# Altium schematic sheet Power_Supply.SchDoc
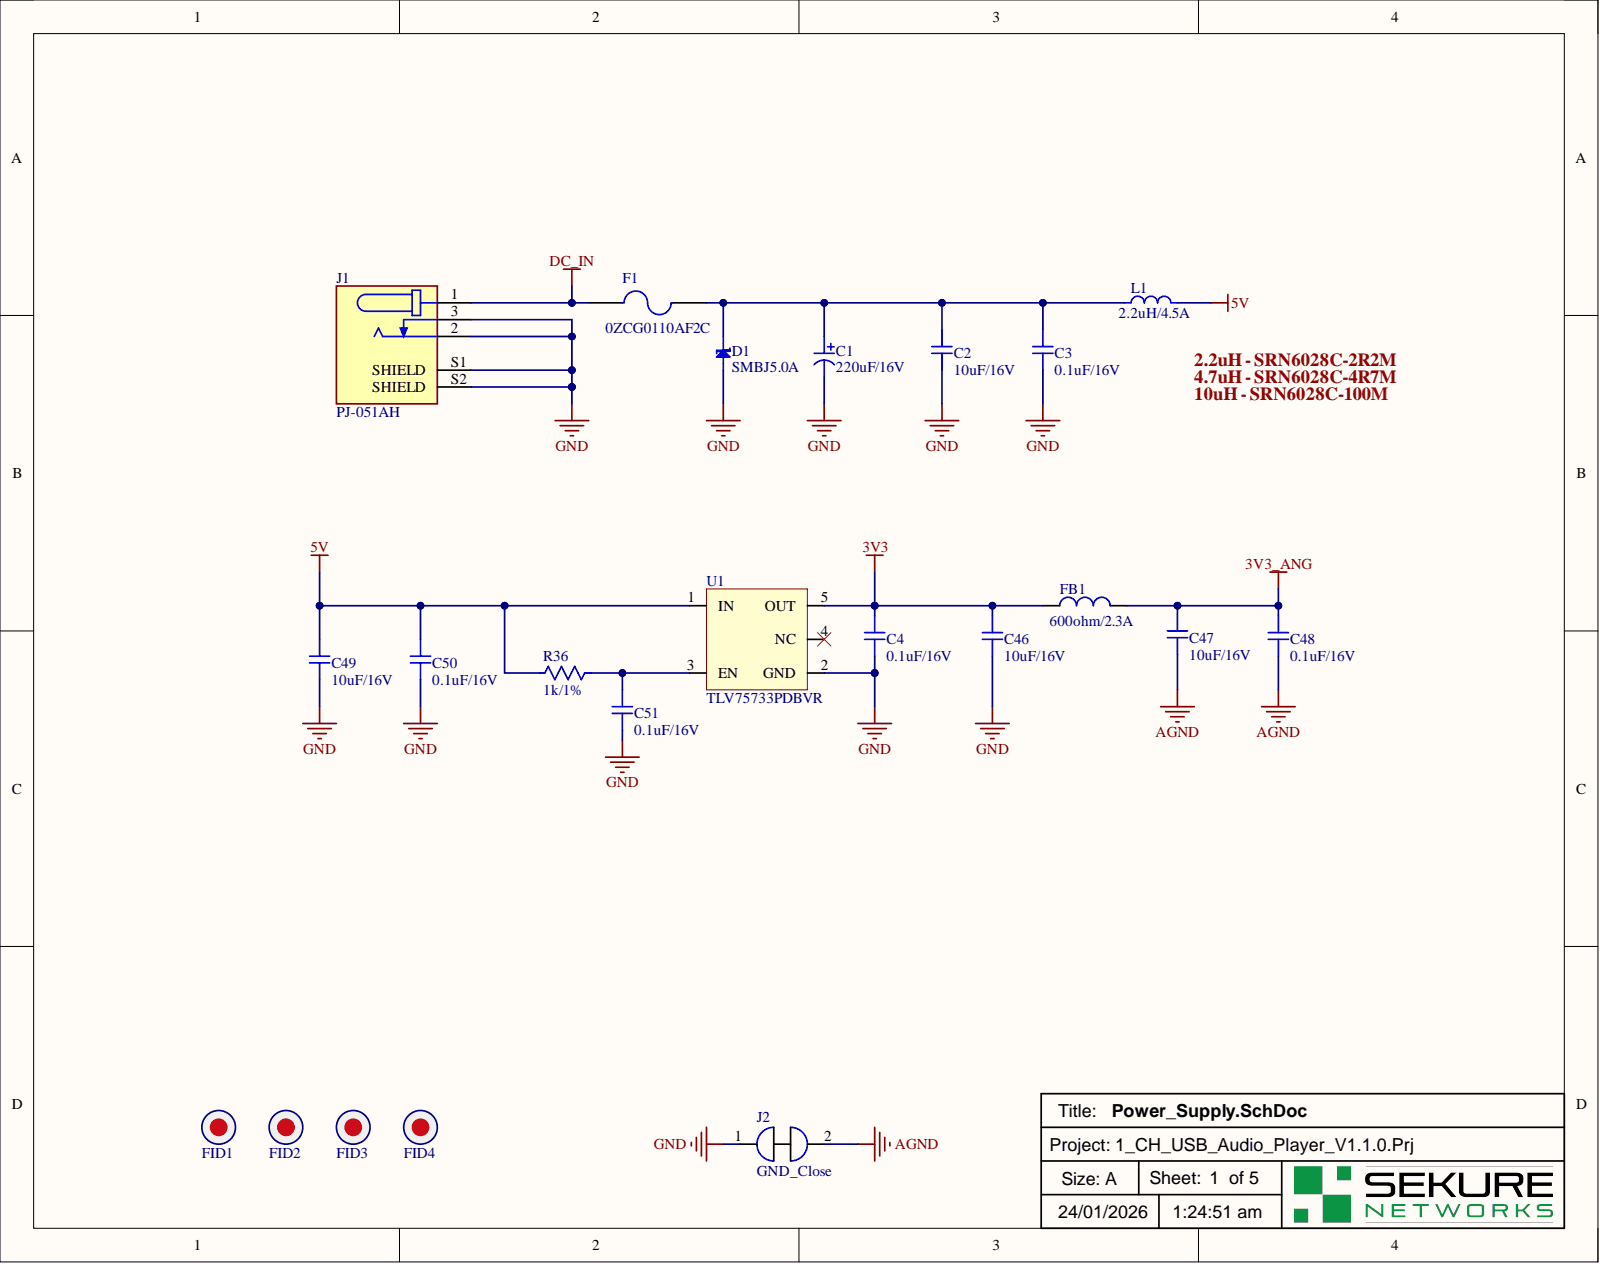
[diagram p0: Power_Supply.SchDoc]

## Parts
C1  value 220uF/16V  [CAP SMD 6.6 x 6.6]  (hidden comment: Radial Capacitor)
C2  value 10uF/16V  [Cap 0805]  (hidden comment: Ceramic Capacitor)
C3  value 0.1uF/16V  [CAP 0603]  (hidden comment: Ceramic Capacitor)
C4  value 0.1uF/16V  [CAP 0603]  (hidden comment: Ceramic Capacitor)
C46  value 10uF/16V  [Cap 0805]  (hidden comment: Ceramic Capacitor)
C47  value 10uF/16V  [Cap 0805]  (hidden comment: Ceramic Capacitor)
C48  value 0.1uF/16V  [CAP 0603]  (hidden comment: Ceramic Capacitor)
C49  value 10uF/16V  [Cap 0805]  (hidden comment: Ceramic Capacitor)
C50  value 0.1uF/16V  [CAP 0603]  (hidden comment: Ceramic Capacitor)
C51  value 0.1uF/16V  [CAP 0603]  (hidden comment: Ceramic Capacitor)
D1  value SMBJ5.0A  [DO-214AA, SMB]  (hidden comment: TVS Diode)
F1  0ZCG0110AF2C  [1812]
FB1  value 600ohm/2.3A  [IND 0805]  (hidden comment: Ferrite Bead)
FID1  Fiducal marks  [Fiducial mark]  (value not drawn)  (no BOM)
FID2  Fiducal marks  [Fiducial mark]  (value not drawn)  (no BOM)
FID3  Fiducal marks  [Fiducial mark]  (value not drawn)  (no BOM)
FID4  Fiducal marks  [Fiducial mark]  (value not drawn)  (no BOM)
J1  value PJ-051AH  [PJ-051AH]  (hidden comment: Connector)
J2  value GND_Close  [Net tie]  (hidden comment: Solder Bridge)
L1  value 2.2uH/4.5A  [INDP6060X45N]  (hidden comment: Inductor)
R36  value 1k/1%  [RES 0603]  (hidden comment: Resistor)
U1  value TLV75733PDBVR  [SOT23-5]  (hidden comment: Regulator)

## Nets
3V3: C4.1 C46.2 FB1.1 U1.5(OUT)
3V3_ANG: C47.2 C48.1 FB1.2
5V: C49.2 C50.2 L1.2 R36.2 U1.1(IN)
AGND: C47.1 C48.2 J2.2
DC_IN: F1.1 J1.1
GND: C1.2 C2.1 C3.2 C4.2 C46.1 C49.1 C50.1 C51.1 D1.2 J1.2 J1.3 J1.S1(SHIELD) J1.S2(SHIELD) J2.1 U1.2(GND)
NetC1_1: C1.1 C2.2 C3.1 D1.1 F1.2 L1.1
NetC51_2: C51.2 R36.1 U1.3(EN)

Not connected: FID1 0; FID2 0; FID3 0; FID4 0; U1 4(NC)

## Library data of the parts
C1 = EEEFK1C221XP: Radial Can - SMD ALUMINUM ELECTROLYTIC CAPACITOR FK 16V 220muF 卤20% 0.248Dia 6.30mm; Manufacturer: Panasonic; Manufacturer Lifecycle Status: ACTIVE; ESR (Equivalent Series Resistance): 340mΩ; Leakage Current: 35.2µA; Life (Hours): 2000hours; Material: Aluminium; Polarity: Polar; Ripple Current: 280mA; Tolerance: 20%; Case/Package: Radial; Category: Aluminum Electrolytic Capacitors; Component Kind: Standard; ComponentLink1Description: https://datasheet.octopart.com:443/EEEFK1C221XP-Panasonic-datasheet-169278531.pdf?src-supplier=IHS; ComponentLink1URL: https://datasheet.octopart.com:443/EEEFK1C221XP-Panasonic-datasheet-169278531.pdf?src-supplier=IHS; ComponentLink2Description: https://datasheet.octopart.com:443/EEEFK1C221XP-Panasonic-datasheet-62287436.pdf?src-supplier=Newark; ComponentLink2URL: https://datasheet.octopart.com:443/EEEFK1C221XP-Panasonic-datasheet-62287436.pdf?src-supplier=Newark; ComponentLink3Description: https://datasheet.octopart.com:443/EEEFK1C221XP-Panasonic-datasheet-8854493.pdf?src-supplier=Newark; ComponentLink3URL: https://datasheet.octopart.com:443/EEEFK1C221XP-Panasonic-datasheet-8854493.pdf?src-supplier=Newark; ComponentLink4Description: https://datasheet.octopart.com:443/EEEFK1C221XP-Panasonic-datasheet-61627622.pdf?src-supplier=Newark; ComponentLink4URL: https://datasheet.octopart.com:443/EEEFK1C221XP-Panasonic-datasheet-61627622.pdf?src-supplier=Newark; ComponentLink5Description: https://datasheet.octopart.com:443/EEE-FK1C221XP-Panasonic---ECG-datasheet-8852369.pdf?src-supplier=Newark; ComponentLink5URL: https://datasheet.octopart.com:443/EEE-FK1C221XP-Panasonic---ECG-datasheet-8852369.pdf?src-supplier=Newark; ComponentLink6Description: https://datasheet.octopart.com:443/EEE-FK1C221XP-Panasonic---ECG-datasheet-8712906.pdf?src-supplier=Newark; ComponentLink6URL: https://datasheet.octopart.com:443/EEE-FK1C221XP-Panasonic---ECG-datasheet-8712906.pdf?src-supplier=Newark; ComponentLink7Description: https://datasheet.octopart.com:443/EEE-FK1C221XP-Panasonic---ECG-datasheet-13278598.pdf?src-supplier=Newark; ComponentLink7URL: https://datasheet.octopart.com:443/EEE-FK1C221XP-Panasonic---ECG-datasheet-13278598.pdf?src-supplier=Newark; ComponentLink8Description: https://datasheet.octopart.com:443/EEE-FK1C221XP-Panasonic-datasheet-22087609.pdf?src-supplier=Newark; ComponentLink8URL: https://datasheet.octopart.com:443/EEE-FK1C221XP-Panasonic-datasheet-22087609.pdf?src-supplier=Newark; ComponentLink9Description: https://datasheet.octopart.com:443/EEEFK1C221XP-Panasonic-datasheet-138897395.pdf?src-supplier=Upverter; ComponentLink9URL: https://datasheet.octopart.com:443/EEEFK1C221XP-Panasonic-datasheet-138897395.pdf?src-supplier=Upverter; ComponentLink10Description: https://datasheet.octopart.com:443/EEEFK1C221XP-Panasonic-datasheet-13266987.pdf?src-supplier=RS+(Formerly+Allied+Electronics); ComponentLink10URL: https://datasheet.octopart.com:443/EEEFK1C221XP-Panasonic-datasheet-13266987.pdf?src-supplier=RS+(Formerly+Allied+Electronics); ComponentLink11Description: https://datasheet.octopart.com:443/EEEFK1C221XP-Panasonic-datasheet-8711795.pdf?src-supplier=Future+Electronics; ComponentLink11URL: https://datasheet.octopart.com:443/EEEFK1C221XP-Panasonic-datasheet-8711795.pdf?src-supplier=Future+Electronics; ComponentLink12Description: https://datasheet.octopart.com:443/EEEFK1C221XP-Panasonic-datasheet-5313801.pdf?src-supplier=Future+Electronics; ComponentLink12URL: https://datasheet.octopart.com:443/EEEFK1C221XP-Panasonic-datasheet-5313801.pdf?src-supplier=Future+Electronics; ComponentLink13Description: https://datasheet.octopart.com:443/EEE-FK1C221XP-Panasonic-datasheet-150469824.pdf?src-supplier=Master+Electronics; ComponentLink13URL: https://datasheet.octopart.com:443/EEE-FK1C221XP-Panasonic-datasheet-150469824.pdf?src-supplier=Master+Electronics; ComponentLink14Description: https://datasheet.octopart.com:443/EEEFK1C221XP-Panasonic-datasheet-11546739.pdf?src-supplier=Electro+Sonic; ComponentLink14URL: https://datasheet.octopart.com:443/EEEFK1C221XP-Panasonic-datasheet-11546739.pdf?src-supplier=Electro+Sonic; ComponentLink15Description: https://sigma.octopart.com:443/150570760/technical_drawing/Panasonic-EEEFK1C221XP.pdf?src-supplier=Panasonic+SCT; ComponentLink15URL: https://sigma.octopart.com:443/150570760/technical_drawing/Panasonic-EEEFK1C221XP.pdf?src-supplier=Panasonic+SCT; ComponentLink16Description: https://datasheet.octopart.com:443/EEEFK1C221XP-Panasonic-datasheet-136531309.pdf?src-supplier=TME; ComponentLink16URL: https://datasheet.octopart.com:443/EEEFK1C221XP-Panasonic-datasheet-136531309.pdf?src-supplier=TME; ComponentLink17Description: https://datasheet.octopart.com:443/EEEFK1C221XP-Panasonic-datasheet-8360981.pdf?src-supplier=Farnell; ComponentLink17URL: https://datasheet.octopart.com:443/EEEFK1C221XP-Panasonic-datasheet-8360981.pdf?src-supplier=Farnell; ComponentLink18Description: https://datasheet.octopart.com:443/EEEFK1C221XP-Panasonic-datasheet-48.pdf?src-supplier=Farnell; ComponentLink18URL: https://datasheet.octopart.com:443/EEEFK1C221XP-Panasonic-datasheet-48.pdf?src-supplier=Farnell; ComponentLink19Description: https://datasheet.octopart.com:443/EEE-FK1C221XP-Panasonic-datasheet-8161.pdf?src-supplier=DigiKey; ComponentLink19URL: https://datasheet.octopart.com:443/EEE-FK1C221XP-Panasonic-datasheet-8161.pdf?src-supplier=DigiKey; Contact Plating: Tin Bismuth; Diameter: 6.3mm; DigiKey: PCE3788CT-ND; Height: 7.7mm; Height - Seated (Max): 8.001mm; Impedance: 340mΩ; Lead Free: Lead Free; Length: 7.7mm; Library Name: Radial Capacitors.IntLib; Lifecycle Status: Production; Max Operating Temperature: 105°C; Min Operating Temperature: -55°C; Mounting Technology: Surface Mount; Number of Terminals: 2; P/N: EEEFK1C221XP; Packaging: Cut Tape; Part Series: FK; Pricing: 1=0.61, 10=0.383, 50=0.2856, 100=0.2546 (USD); Radiation Hardening: No; REACH SVHC: Yes; Ripple Current (AC): 280mA; RoHS: Compliant; Schedule B: 8532220020, 8532220020|8532220020|8532220020|8532220020|8532220020; Stock: 13441; Supplier: DigiKey; Termination: SMD/SMT; Voltage: 16V; Voltage Rating: 16V; Voltage Rating (DC): 16V; Width: 6.6mm
C2 C46 C47 C49 = CL21A106KOQNNNE: 16V 10uF X5R ±10% 0805 Multilayer Ceramic Capacitors MLCC - SMD/SMT ROHS; Manufacturer: Samsung Electro-Mechanics; Case Code (Imperial): 0805; Case Code (Metric): 2012; Case/Package: 0805; Category: Ceramic Capacitors; China RoHS: Compliant; Component Kind: Standard; ComponentLink1Description: https://datasheet.octopart.com:443/CL21A106KOQNNNE-Samsung-Electro-Mechanics-datasheet-136031799.pdf?src-supplier=IHS; ComponentLink1URL: https://datasheet.octopart.com:443/CL21A106KOQNNNE-Samsung-Electro-Mechanics-datasheet-136031799.pdf?src-supplier=IHS; ComponentLink2Description: https://datasheet.octopart.com/CL21A106KOQNNNE-Samsung-Electro-Mechanics-datasheet-8324245.pdf; ComponentLink2URL: https://datasheet.octopart.com/CL21A106KOQNNNE-Samsung-Electro-Mechanics-datasheet-8324245.pdf; ComponentLink3Description: https://datasheet.octopart.com/CL21A106KOQNNNE-Samsung-Electro-Mechanics-datasheet-97040617.pdf; ComponentLink3URL: https://datasheet.octopart.com/CL21A106KOQNNNE-Samsung-Electro-Mechanics-datasheet-97040617.pdf; ComponentLink4Description: https://datasheet.octopart.com:443/CL21A106KOQNNNE-Samsung-Electro-Mechanics-datasheet-130012977.pdf?src-supplier=Future+Electronics; ComponentLink4URL: https://datasheet.octopart.com:443/CL21A106KOQNNNE-Samsung-Electro-Mechanics-datasheet-130012977.pdf?src-supplier=Future+Electronics; ComponentLink5Description: https://datasheet.octopart.com:443/CL21A106KOQNNNE-Samsung-Electro-Mechanics-datasheet-82614846.pdf?src-supplier=Future+Electronics; ComponentLink5URL: https://datasheet.octopart.com:443/CL21A106KOQNNNE-Samsung-Electro-Mechanics-datasheet-82614846.pdf?src-supplier=Future+Electronics; ComponentLink6Description: https://datasheet.octopart.com:443/CL21A106KOQNNNE-Samsung-Electro-Mechanics-datasheet-81462122.pdf?src-supplier=Future+Electronics; ComponentLink6URL: https://datasheet.octopart.com:443/CL21A106KOQNNNE-Samsung-Electro-Mechanics-datasheet-81462122.pdf?src-supplier=Future+Electronics; ComponentLink7Description: https://datasheet.octopart.com:443/CL21A106KOQNNNE-Samsung-datasheet-48647542.pdf?src-supplier=Future+Electronics; ComponentLink7URL: https://datasheet.octopart.com:443/CL21A106KOQNNNE-Samsung-datasheet-48647542.pdf?src-supplier=Future+Electronics; ComponentLink8Description: https://datasheet.octopart.com:443/CL21A106KOQNNNE-Samsung-datasheet-26669228.pdf?src-supplier=Future+Electronics; ComponentLink8URL: https://datasheet.octopart.com:443/CL21A106KOQNNNE-Samsung-datasheet-26669228.pdf?src-supplier=Future+Electronics; ComponentLink9Description: https://datasheet.octopart.com:443/CL21A106KOQNNNE-Samsung-Electro-Mechanics-datasheet-149154152.pdf?src-supplier=Future+Electronics; ComponentLink9URL: https://datasheet.octopart.com:443/CL21A106KOQNNNE-Samsung-Electro-Mechanics-datasheet-149154152.pdf?src-supplier=Future+Electronics; ComponentLink10Description: https://datasheet.octopart.com:443/CL21A106KOQNNNE-Samsung-datasheet-22147434.pdf?src-supplier=TME; ComponentLink10URL: https://datasheet.octopart.com:443/CL21A106KOQNNNE-Samsung-datasheet-22147434.pdf?src-supplier=TME; Depth: 1.25mm; Dielectric: X5R; Dielectric Material: Ceramic; DigiKey: 1276-1096-1-ND; Height: 1.4mm; Lead Free: Lead Free; Length: 2mm; Library Name: Ceramic Capacitors.IntLib; Lifecycle Status: Production; Material: Ceramic; Max Operating Temperature: 85°C; Min Operating Temperature: -55°C; Mounting Technology: Surface Mount; Number of Terminals: 2; P/N: CL21A106KOQNNNE; Pricing: 1=0.08, 10=0.021, 50=0.02, 100=0.0192, 500=0.0144, 1000=0.0128 (USD); Radiation Hardening: No; REACH SVHC: Yes; RoHS: Compliant; Schedule B: 8532240020, 8532240020|8532240020|8532240020|8532240020|8532240020|8532240020|8532240020|8532240020|8532240020|8532240020|8532240020|8532240020|8532240020|8532240020|8532240020|8532240020|8532240020|8532240020|8532240020|8532240020|8532240020|8532240020|8532240020|85; Stock: 1352697; Supplier: DigiKey; Thickness: 1.397mm; Tolerance: 10%; Voltage: 16V; Voltage Rating: 16V; Voltage Rating (DC): 16V; Width: 1.25mm
C3 C4 C48 C50 C51 = CL10B104KO8NNNC: 16V 100nF X7R ±10% 0603 Multilayer Ceramic Capacitors MLCC - SMD/SMT ROHS; Manufacturer: Samsung Electro-Mechanics; Case Code (Imperial): 0603; Case Code (Metric): 1608; Case/Package: 0603; Category: Ceramic Capacitors; China RoHS: Compliant; Component Kind: Standard; ComponentLink1Description: https://datasheet.octopart.com:443/CL10B104KO8NNNC-Samsung-Electro-Mechanics-datasheet-136482305.pdf?src-supplier=IHS; ComponentLink1URL: https://datasheet.octopart.com:443/CL10B104KO8NNNC-Samsung-Electro-Mechanics-datasheet-136482305.pdf?src-supplier=IHS; ComponentLink2Description: https://datasheet.octopart.com/CL10B104KO8NNNC-Samsung-Electro-Mechanics-datasheet-8324245.pdf; ComponentLink2URL: https://datasheet.octopart.com/CL10B104KO8NNNC-Samsung-Electro-Mechanics-datasheet-8324245.pdf; ComponentLink3Description: https://datasheet.octopart.com/CL10B104KO8NNNC-Samsung-Electro-Mechanics-datasheet-97040617.pdf; ComponentLink3URL: https://datasheet.octopart.com/CL10B104KO8NNNC-Samsung-Electro-Mechanics-datasheet-97040617.pdf; ComponentLink4Description: https://datasheet.octopart.com:443/CL10B104KO8NNNC-Samsung-Electro-Mechanics-datasheet-116890973.pdf?src-supplier=Future+Electronics; ComponentLink4URL: https://datasheet.octopart.com:443/CL10B104KO8NNNC-Samsung-Electro-Mechanics-datasheet-116890973.pdf?src-supplier=Future+Electronics; ComponentLink5Description: https://datasheet.octopart.com:443/CL10B104KO8NNNC-Samsung-Electro-Mechanics-datasheet-81461844.pdf?src-supplier=Future+Electronics; ComponentLink5URL: https://datasheet.octopart.com:443/CL10B104KO8NNNC-Samsung-Electro-Mechanics-datasheet-81461844.pdf?src-supplier=Future+Electronics; ComponentLink6Description: https://datasheet.octopart.com:443/CL10B104KO8NNNC-Samsung-datasheet-42770384.pdf?src-supplier=Future+Electronics; ComponentLink6URL: https://datasheet.octopart.com:443/CL10B104KO8NNNC-Samsung-datasheet-42770384.pdf?src-supplier=Future+Electronics; ComponentLink7Description: https://datasheet.octopart.com:443/CL10B104KO8NNNC-Samsung-datasheet-26589157.pdf?src-supplier=Future+Electronics; ComponentLink7URL: https://datasheet.octopart.com:443/CL10B104KO8NNNC-Samsung-datasheet-26589157.pdf?src-supplier=Future+Electronics; ComponentLink8Description: https://datasheet.octopart.com:443/CL10B104KO8NNNC-Samsung-Electro-Mechanics-datasheet-149136789.pdf?src-supplier=Future+Electronics; ComponentLink8URL: https://datasheet.octopart.com:443/CL10B104KO8NNNC-Samsung-Electro-Mechanics-datasheet-149136789.pdf?src-supplier=Future+Electronics; ComponentLink9Description: https://datasheet.octopart.com:443/CL10B104KO8NNNC-Samsung-datasheet-8326407.pdf?src-supplier=TME; ComponentLink9URL: https://datasheet.octopart.com:443/CL10B104KO8NNNC-Samsung-datasheet-8326407.pdf?src-supplier=TME; ComponentLink10Description: https://datasheet.octopart.com:443/CL10B104KO8NNNC-Samsung-Electro-Mechanics-datasheet-11890603.pdf?src-supplier=Arrow+Electronics; ComponentLink10URL: https://datasheet.octopart.com:443/CL10B104KO8NNNC-Samsung-Electro-Mechanics-datasheet-11890603.pdf?src-supplier=Arrow+Electronics; Contact Plating: Tin; Depth: 800µm; Dielectric: X7R; Dielectric Material: Ceramic; DigiKey: 1276-1005-1-ND; Height: 800µm; Lead Free: Lead Free; Length: 1.6mm; Library Name: Ceramic Capacitors.IntLib; Lifecycle Status: Production; Material: Ceramic; Max Operating Temperature: 125°C; Min Operating Temperature: -55°C; Mounting Technology: Surface Mount; Number of Terminals: 2; P/N: CL10B104KO8NNNC; Pricing: 1=0.1, 10=0.026, 50=0.0172, 100=0.0146, 500=0.0103, 1000=0.00899 (USD); Radiation Hardening: No; REACH SVHC: Yes; RoHS: Compliant; Schedule B: 8532240020, 8532240020|8532240020, 8532240020|8532240020|8532240020, 8532240020|8532240020|8532240020|8532240020; Stock: 2399591; Supplier: DigiKey; Thickness: 889µm; Tolerance: 10%; Voltage: 16V; Voltage Rating: 16V; Voltage Rating (DC): 16V; Width: 800µm
D1 = SMBJ5.0A: SMBJ Series 5 W 7 V Uni-Directional Surface Mount TVS Diode - SMB; Manufacturer: Littelfuse; Breakdown Voltage: 6.7V; Clamping Voltage: 9.2V; Current: 68A; Direction: Unidirectional; Element Configuration: Single; ESD Protection: Yes; Forward Voltage: 3.5V; Leakage Current: 800µA; Max Breakdown Voltage: 7V; Max Junction Temperature (Tj): 150°C; Max Power Dissipation: 5W; Max Surge Current: 65.3A; Min Breakdown Voltage: 6.4V; Number of Channels: 1; Operating Supply Voltage: 5V; Peak Pulse Power: 600W; Power Line Protection: No; Test Current: 10mA; Case/Package: SMB; Category: TVS Diodes; Component Kind: Standard; ComponentLink1Description: https://datasheet.octopart.com:443/SMBJ5.0A-Littelfuse-datasheet-180609570.pdf?src-supplier=IHS; ComponentLink1URL: https://datasheet.octopart.com:443/SMBJ5.0A-Littelfuse-datasheet-180609570.pdf?src-supplier=IHS; ComponentLink2Description: https://datasheet.octopart.com:443/SMBJ5.0A-Littelfuse-datasheet-98958142.pdf?src-supplier=LKR; ComponentLink2URL: https://datasheet.octopart.com:443/SMBJ5.0A-Littelfuse-datasheet-98958142.pdf?src-supplier=LKR; ComponentLink3Description: https://datasheet.octopart.com:443/SMBJ5.0A-Littelfuse-datasheet-63710821.pdf?src-supplier=Farnell; ComponentLink3URL: https://datasheet.octopart.com:443/SMBJ5.0A-Littelfuse-datasheet-63710821.pdf?src-supplier=Farnell; ComponentLink4Description: https://datasheet.octopart.com:443/SMBJ5.0A-Littelfuse-datasheet-145017494.pdf?src-supplier=Farnell; ComponentLink4URL: https://datasheet.octopart.com:443/SMBJ5.0A-Littelfuse-datasheet-145017494.pdf?src-supplier=Farnell; ComponentLink5Description: https://datasheet.octopart.com:443/SMBJ5.0A-Littelfuse-datasheet-123574.pdf?src-supplier=element14+APAC; ComponentLink5URL: https://datasheet.octopart.com:443/SMBJ5.0A-Littelfuse-datasheet-123574.pdf?src-supplier=element14+APAC; ComponentLink6Description: https://datasheet.octopart.com:443/SMBJ5.0A-Littelfuse-datasheet-12567566.pdf?src-supplier=element14+APAC; ComponentLink6URL: https://datasheet.octopart.com:443/SMBJ5.0A-Littelfuse-datasheet-12567566.pdf?src-supplier=element14+APAC; ComponentLink7Description: https://datasheet.octopart.com:443/SMBJ5.0A-Littelfuse-datasheet-10854588.pdf?src-supplier=TME; ComponentLink7URL: https://datasheet.octopart.com:443/SMBJ5.0A-Littelfuse-datasheet-10854588.pdf?src-supplier=TME; ComponentLink8Description: https://datasheet.octopart.com:443/SMBJ5.0A-Littelfuse-datasheet-165289144.pdf?src-supplier=Electro+Sonic; ComponentLink8URL: https://datasheet.octopart.com:443/SMBJ5.0A-Littelfuse-datasheet-165289144.pdf?src-supplier=Electro+Sonic; ComponentLink9Description: https://datasheet.octopart.com:443/SMBJ5.0A-Littelfuse-datasheet-27889791.pdf?src-supplier=Future+Electronics; ComponentLink9URL: https://datasheet.octopart.com:443/SMBJ5.0A-Littelfuse-datasheet-27889791.pdf?src-supplier=Future+Electronics; ComponentLink10Description: https://datasheet.octopart.com:443/SMBJ5.0A-Littelfuse-datasheet-5319440.pdf?src-supplier=Future+Electronics; ComponentLink10URL: https://datasheet.octopart.com:443/SMBJ5.0A-Littelfuse-datasheet-5319440.pdf?src-supplier=Future+Electronics; ComponentLink11Description: https://datasheet.octopart.com:443/SMBJ5.0A-Littelfuse-datasheet-871.pdf?src-supplier=DigiKey; ComponentLink11URL: https://datasheet.octopart.com:443/SMBJ5.0A-Littelfuse-datasheet-871.pdf?src-supplier=DigiKey; ComponentLink12Description: https://datasheet.octopart.com:443/SMBJ5.0A-Littelfuse-datasheet-141402787.pdf?src-supplier=JRH+Electronics; ComponentLink12URL: https://datasheet.octopart.com:443/SMBJ5.0A-Littelfuse-datasheet-141402787.pdf?src-supplier=JRH+Electronics; ComponentLink13Description: https://datasheet.octopart.com:443/SMBJ5.0A-Littelfuse-datasheet-9701889.pdf?src-supplier=RS+(Formerly+Allied+Electronics); ComponentLink13URL: https://datasheet.octopart.com:443/SMBJ5.0A-Littelfuse-datasheet-9701889.pdf?src-supplier=RS+(Formerly+Allied+Electronics); ComponentLink14Description: https://datasheet.octopart.com:443/SMBJ5.0A-Littelfuse-datasheet-22888.pdf?src-supplier=RS+(Formerly+Allied+Electronics); ComponentLink14URL: https://datasheet.octopart.com:443/SMBJ5.0A-Littelfuse-datasheet-22888.pdf?src-supplier=RS+(Formerly+Allied+Electronics); ComponentLink15Description: https://datasheet.octopart.com:443/SMBJ5.0A-Littelfuse-datasheet-10404348.pdf?src-supplier=Jameco; ComponentLink15URL: https://datasheet.octopart.com:443/SMBJ5.0A-Littelfuse-datasheet-10404348.pdf?src-supplier=Jameco; Composition: Zener; DigiKey: SMBJ5.0ALFCT-ND; Height: 2.61mm; Lead Free: Lead Free; Length: 4.5466mm; Library Name: Diode.IntLib; Lifecycle Status: Production; Max Operating Temperature: 150°C; Max Reverse Leakage Current: 800µA; Min Operating Temperature: -65°C; Mounting Technology: Surface Mount; Number of Elements: 1; Number of Terminals: 2; Number of Unidirectional Channels: 1; P/N: SMBJ5.0A; Packaging: Cut Tape; Peak Pulse Current: 65.3A; Peak Reverse Current: 800µA; Pins: 2; Polarity: Unidirectional; Power Dissipation: 600W; Power Rating: 600W; Pricing: 1=0.21, 10=0.167, 100=0.105 (USD); Radiation Hardening: No; REACH SVHC: No; Reverse Breakdown Voltage: 6.4V; Reverse Standoff Voltage: 5V; Reverse Voltage: 5V; RoHS: Compliant; Schedule B: 8541100080, 8541100080|8541100080, 8541100080|8541100080|8541100080, 8541100080|8541100080|8541100080|8541100080; Stock: 117965; Supplier: DigiKey; Termination: SMD/SMT; Voltage: 5V; Voltage Rating (DC): 5V; Width: 3.937mm
F1 = Fuse: PTC RESETTBLE 1.1A 16V CHIP 1812; Component Kind: Standard; Library Name: Fuse.SchLib; Part: 0ZCG0110AF2C; Value: 0ZCG0110AF2C
FB1 = BLM21HE601SN1L: Ferrite Beads 0805 600ohm 0.055ohm 25%Simulate & compare characteristics in SimSurfing; Manufacturer: Murata; Current Rating: 1.5A; DC Resistance (DCR): 55mΩ; Impedance: 600Ω; Number of Circuits: 1; RMS Current (Irms): 2.3A; Test Frequency: 100MHz; Tolerance: 25%; Case/Package: 0805; Category: Ferrite Beads and Chips; Component Kind: Standard; ComponentLink1Description: https://datasheet.octopart.com:443/BLM21HE601SN1L-Murata-datasheet-180807080.pdf?src-supplier=IHS; ComponentLink1URL: https://datasheet.octopart.com:443/BLM21HE601SN1L-Murata-datasheet-180807080.pdf?src-supplier=IHS; ComponentLink2Description: https://datasheet.octopart.com/BLM21HE601SN1L-Murata-datasheet-37129980.pdf; ComponentLink2URL: https://datasheet.octopart.com/BLM21HE601SN1L-Murata-datasheet-37129980.pdf; ComponentLink3Description: http://sigma.octopart.com:80/reach_statement/Murata-company-16.pdf?src-supplier=Compliance+Statements; ComponentLink3URL: http://sigma.octopart.com:80/reach_statement/Murata-company-16.pdf?src-supplier=Compliance+Statements; Core Material: Ferrite; DigiKey: 490-BLM21HE601SN1LCT-ND; ESR: 55mΩ; Height: 1.25mm; Length: 2mm; Library Name: Inductors_NEW.IntLib; Lifecycle Status: Production; Max Operating Temperature: 125°C; Min Operating Temperature: -55°C; Number of Terminals: 2; P/N: BLM21HE601SN1L; Pricing: 1=0.41, 10=0.287, 25=0.2484, 50=0.2218, 100=0.1972, 250=0.1676, 500=0.14712, 1000=0.13464 (USD); REACH SVHC: Yes; RoHS: Compliant; Stock: 12825; Supplier: DigiKey; Width: 1.25mm
FID1-FID4 = Fiducial mark: Fiducial mark mini  in top placement.; Number: 1; Library Name: Connectors.IntLib
J1 = PJ-051AH: 2.0 x 6.0 mm, 5.0 A, Horizontal, Through Hole, Shielded, Dc Power Jack Connector; Manufacturer: CUI Devices; Category: Power Connectors; Component Kind: Standard; ComponentLink1Description: https://www.cuidevices.com/product/resource/digikeypdf/pj-051ah.pdf?src-supplier=Digikey; ComponentLink1URL: https://www.cuidevices.com/product/resource/digikeypdf/pj-051ah.pdf?src-supplier=Digikey; ComponentLink2Description: https://datasheet.octopart.com/PJ-051AH-CUI-Devices-datasheet-137760691.pdf?src-supplier=Future+Electronics; ComponentLink2URL: https://datasheet.octopart.com/PJ-051AH-CUI-Devices-datasheet-137760691.pdf?src-supplier=Future+Electronics; ComponentLink3Description: https://datasheet.octopart.com/PJ-051AH-CUI-Devices-datasheet-141000361.pdf?src-supplier=Master+Electronics; ComponentLink3URL: https://datasheet.octopart.com/PJ-051AH-CUI-Devices-datasheet-141000361.pdf?src-supplier=Master+Electronics; DigiKey: CP-051AH-ND; Library Name: Connectors.SchLib; P/N: PJ-051AH; Pricing: 1=0.63, 10=0.532, 25=0.5108, 50=0.4998, 100=0.4781, 250=0.43464, 500=0.39118, 1000=0.38261 (USD); RoHS: Yes; Stock: 71631; Supplier: Digikey
J2 = GND_Close: GND Polygon Close; Assembly: DNP; Component Kind: Net Tie; Library Name: Connectors.SchLib
L1 = SRN6028C-2R2M: General Purpose Inductor, 2.2uH, 20%, 1 Element, Ferrite-Core, SMD, 2424; Manufacturer: Bourns; DC Resistance (DCR): 18mΩ; Max Current Rating: 4.5A; Number of Circuits: 1; Self Resonant Frequency: 60MHz; Shielding: Yes; Test Frequency: 100kHz; Case/Package: 2424; Category: Fixed Inductors; Component Kind: Standard; ComponentLink1Description: https://datasheet.octopart.com:443/SRN6028C-2R2M-Bourns-datasheet-179983039.pdf?src-supplier=IHS; ComponentLink1URL: https://datasheet.octopart.com:443/SRN6028C-2R2M-Bourns-datasheet-179983039.pdf?src-supplier=IHS; ComponentLink2Description: http://sigma.octopart.com:80/conflict_mineral_statement/Bourns-company-36.pdf?src-supplier=Compliance+Statements; ComponentLink2URL: http://sigma.octopart.com:80/conflict_mineral_statement/Bourns-company-36.pdf?src-supplier=Compliance+Statements; Core Material: Ferrite; DigiKey: 118-SRN6028C-2R2MCT-ND; Height: 2.6mm; Library Name: Inductors_NEW.IntLib; Lifecycle Status: Production; Max Operating Temperature: 125°C; Min Operating Temperature: -40°C; Number of Terminals: 2; P/N: SRN6028C-2R2M; Pricing: 1=0.38, 10=0.312, 100=0.2569 (USD); REACH SVHC: Yes; RoHS: Compliant; Stock: 902; Supplier: DigiKey; Tolerance: 20%; Width: 6mm
R36 = ERJ-U03F1001V: Resistor, thick film, SMD 0603 (1608), 1 kΩ, 0.1 W, ±1 %, ERJU03F1001V; Manufacturer: Panasonic; Manufacturer Lifecycle Status: ACTIVE; Part Series: Anti-Sulfurated Thick Film Chip Resistors; Current Rating: 1A; Power Dissipation: 100mW; Temperature Coefficient: 100ppm/°C; Tolerance: 1%; Voltage Rating: 75V; Case Code (Imperial): 0603; Case Code (Metric): 1608; Case/Package: 0603; Category: Chip SMD Resistors; Component Kind: Standard; ComponentLink1Description: https://datasheet.octopart.com:443/ERJ-U03F1001V-Panasonic-datasheet-85390793.pdf?src-supplier=Panasonic; ComponentLink1URL: https://datasheet.octopart.com:443/ERJ-U03F1001V-Panasonic-datasheet-85390793.pdf?src-supplier=Panasonic; ComponentLink2Description: https://datasheet.octopart.com:443/ERJ-U03F1001V-Panasonic-datasheet-11808117.pdf?src-supplier=RS+(Formerly+Allied+Electronics); ComponentLink2URL: https://datasheet.octopart.com:443/ERJ-U03F1001V-Panasonic-datasheet-11808117.pdf?src-supplier=RS+(Formerly+Allied+Electronics); ComponentLink3Description: https://datasheet.octopart.com:443/ERJ-U03F1001V-Panasonic-datasheet-61627662.pdf?src-supplier=Farnell; ComponentLink3URL: https://datasheet.octopart.com:443/ERJ-U03F1001V-Panasonic-datasheet-61627662.pdf?src-supplier=Farnell; ComponentLink4Description: https://datasheet.octopart.com:443/ERJ-U03F1001V-Panasonic-datasheet-184398019.pdf?src-supplier=Electro+Sonic; ComponentLink4URL: https://datasheet.octopart.com:443/ERJ-U03F1001V-Panasonic-datasheet-184398019.pdf?src-supplier=Electro+Sonic; ComponentLink5Description: https://datasheet.octopart.com:443/ERJ-U03F1001V-Panasonic-datasheet-159616995.pdf?src-supplier=Newark; ComponentLink5URL: https://datasheet.octopart.com:443/ERJ-U03F1001V-Panasonic-datasheet-159616995.pdf?src-supplier=Newark; ComponentLink6Description: https://datasheet.octopart.com:443/ERJ-U03F1001V-Panasonic-datasheet-33006993.pdf?src-supplier=Newark; ComponentLink6URL: https://datasheet.octopart.com:443/ERJ-U03F1001V-Panasonic-datasheet-33006993.pdf?src-supplier=Newark; ComponentLink7Description: https://datasheet.octopart.com:443/ERJU03F1001V-Panasonic-datasheet-164871545.pdf?src-supplier=Burklin+Elektronik; ComponentLink7URL: https://datasheet.octopart.com:443/ERJU03F1001V-Panasonic-datasheet-164871545.pdf?src-supplier=Burklin+Elektronik; ComponentLink8Description: https://datasheet.octopart.com/ERJ-U03F1001V-Panasonic-datasheet-78375410.pdf; ComponentLink8URL: https://datasheet.octopart.com/ERJ-U03F1001V-Panasonic-datasheet-78375410.pdf; Composition: Thick Film; Contact Plating: Tin; Depth: 800µm; DigiKey: 10-ERJ-U03F1001VCT-ND; Height: 450µm; Length: 1.6mm; Library Name: Resistors.IntLib; Lifecycle Status: Production; Max Operating Temperature: 155°C; Min Operating Temperature: -55°C; Mounting Technology: Surface Mount; Number of Terminals: 2; P/N: ERJ-U03F1001V; Packaging: Cut Tape; Power Rating: 100mW; Pricing: 1=0.12, 10=0.057, 25=0.12, 50=0.0372, 100=0.0312, 250=0.02572, 500=0.02248, 1000=0.0199 (EUR); REACH SVHC: Yes; RoHS: Compliant; Stock: 30734; Supplier: DigiKey; Termination: SMD/SMT; Voltage Rating (DC): 75V; Weight: 2.012816mg; Width: 800µm; Working Voltage: 75V
U1 = TLV75733PDBVR: 1-A, low-IQ high-accuracy low-dropout (LDO) voltage regulator with enable 5-SOT-23 -40 to 125; Manufacturer: Texas Instruments; Manufacturer Lifecycle Status: ACTIVE; Accuracy: 1%; Dropout Voltage: 1.35V; Max Junction Temperature (Tj): 125°C; Max Supply Voltage: 5.5V; Min Supply Voltage: 1.45V; Number of Outputs: 1; Output Current: 1A; Output Voltage: 3.3V; Case/Package: SOT-23; Category: LDO Voltage Regulators; Component Kind: Standard; ComponentLink1Description: https://sigma.octopart.com:443/177332311/technical_drawing/Texas-Instruments-TLV75733PDBVR.pdf?src-supplier=Texas+Instruments; ComponentLink1URL: https://sigma.octopart.com:443/177332311/technical_drawing/Texas-Instruments-TLV75733PDBVR.pdf?src-supplier=Texas+Instruments; ComponentLink2Description: https://octopart.com:443/opatz8j6/c1?t=oy4Y_2lGBkhpOKX6hVsG3nbMNuQQtQB78HM1AGnqW-j33A4sk_ltt_eVraLZJFOyYK-rPvWDW6RuK9pA2bAZUV9amsXhFEVeCDJmVxHNFInkNHwZ0uWawaFf-e-v-DcjIskcI_XyD3TX6uBIhMdoJqdOPuBlAzx3_W-vwXdRn5zsInk6oU5euxT49kbj8ncjhsspMWkRU_35Ql5bkT1dSfa5jybXBpPaKu22PMV2nieaa9Gt9kYSSWuDTCmpQQMYAZhB8erzbfrslTEzM4TUYFp44E2I07zs3S2GPIDicEwInl1WBh_muv7S3_17Nm55v6bTbHkswIywEDgL9RwlAxeWnbhY-KHil4kRT_wQ9RgX4IbWR1JnFnct-AJ3S0NjQ3HSnd4&src-supplier=Texas+Instruments; ComponentLink2URL: https://octopart.com:443/opatz8j6/c1?t=oy4Y_2lGBkhpOKX6hVsG3nbMNuQQtQB78HM1AGnqW-j33A4sk_ltt_eVraLZJFOyYK-rPvWDW6RuK9pA2bAZUV9amsXhFEVeCDJmVxHNFInkNHwZ0uWawaFf-e-v-DcjIskcI_XyD3TX6uBIhMdoJqdOPuBlAzx3_W-vwXdRn5zsInk6oU5euxT49kbj8ncjhsspMWkRU_35Ql5bkT1dSfa5jybXBpPaKu22PMV2nieaa9Gt9kYSSWuDTCmpQQMYAZhB8erzbfrslTEzM4TUYFp44E2I07zs3S2GPIDicEwInl1WBh_muv7S3_17Nm55v6bTbHkswIywEDgL9RwlAxeWnbhY-KHil4kRT_wQ9RgX4IbWR1JnFnct-AJ3S0NjQ3HSnd4&src-supplier=Texas+Instruments; ComponentLink3Description: https://sigma.octopart.com:443/175570406/technical_drawing/Texas-Instruments-TLV75733PDBVR.pdf?src-supplier=Texas+Instruments; ComponentLink3URL: https://sigma.octopart.com:443/175570406/technical_drawing/Texas-Instruments-TLV75733PDBVR.pdf?src-supplier=Texas+Instruments; ComponentLink4Description: https://sigma.octopart.com:443/169406034/technical_drawing/Texas-Instruments-TLV75733PDBVR.pdf?src-supplier=Texas+Instruments; ComponentLink4URL: https://sigma.octopart.com:443/169406034/technical_drawing/Texas-Instruments-TLV75733PDBVR.pdf?src-supplier=Texas+Instruments; ComponentLink5Description: https://sigma.octopart.com:443/155939316/technical_drawing/Texas-Instruments-TLV75733PDBVR.pdf?src-supplier=Texas+Instruments; ComponentLink5URL: https://sigma.octopart.com:443/155939316/technical_drawing/Texas-Instruments-TLV75733PDBVR.pdf?src-supplier=Texas+Instruments; ComponentLink6Description: https://sigma.octopart.com:443/102331370/technical_drawing/Texas-Instruments-TLV75733PDBVR.pdf?src-supplier=Texas+Instruments; ComponentLink6URL: https://sigma.octopart.com:443/102331370/technical_drawing/Texas-Instruments-TLV75733PDBVR.pdf?src-supplier=Texas+Instruments; ComponentLink7Description: https://datasheet.octopart.com:443/TLV75733PDBVR-Texas-Instruments-datasheet-164419132.pdf?src-supplier=Augswan; ComponentLink7URL: https://datasheet.octopart.com:443/TLV75733PDBVR-Texas-Instruments-datasheet-164419132.pdf?src-supplier=Augswan; ComponentLink8Description: https://datasheet.octopart.com:443/TLV75733PDBVR-Texas-Instruments-datasheet-165804641.pdf?src-supplier=LCSC; ComponentLink8URL: https://datasheet.octopart.com:443/TLV75733PDBVR-Texas-Instruments-datasheet-165804641.pdf?src-supplier=LCSC; ComponentLink9Description: https://datasheet.octopart.com:443/TLV75733PDBVR-Texas-Instruments-datasheet-159117837.pdf?src-supplier=LCSC; ComponentLink9URL: https://datasheet.octopart.com:443/TLV75733PDBVR-Texas-Instruments-datasheet-159117837.pdf?src-supplier=LCSC; ComponentLink10Description: http://sigma.octopart.com:80/conflict_mineral_statement/Texas-Instruments-company-63.pdf?src-supplier=Compliance+Statements; ComponentLink10URL: http://sigma.octopart.com:80/conflict_mineral_statement/Texas-Instruments-company-63.pdf?src-supplier=Compliance+Statements; ComponentLink11Description: http://sigma.octopart.com:80/reach_statement/Texas-Instruments-company-64.pdf?src-supplier=Compliance+Statements; ComponentLink11URL: http://sigma.octopart.com:80/reach_statement/Texas-Instruments-company-64.pdf?src-supplier=Compliance+Statements; DigiKey: 296-50414-1-ND; Height: 1.45mm; Length: 2.9mm; Library Name: Regulators.IntLib; Lifecycle Status: Production; Max Operating Temperature: 125°C; Min Operating Temperature: -40°C; P/N: TLV75733PDBVR; Pins: 5; Pricing: 1=0.33, 10=0.229, 25=0.2032, 100=0.1751, 250=0.16168, 500=0.15358, 1000=0.14692 (USD); RoHS: Compliant; Schedule B: 8542390000, 8542390000|8542390000|8542390000|8542390000|8542390000; Stock: 22389; Supplier: DigiKey; Thickness: 1.2mm; Width: 1.6mm
